# Altium schematic sheet [4]Storage.SchDoc
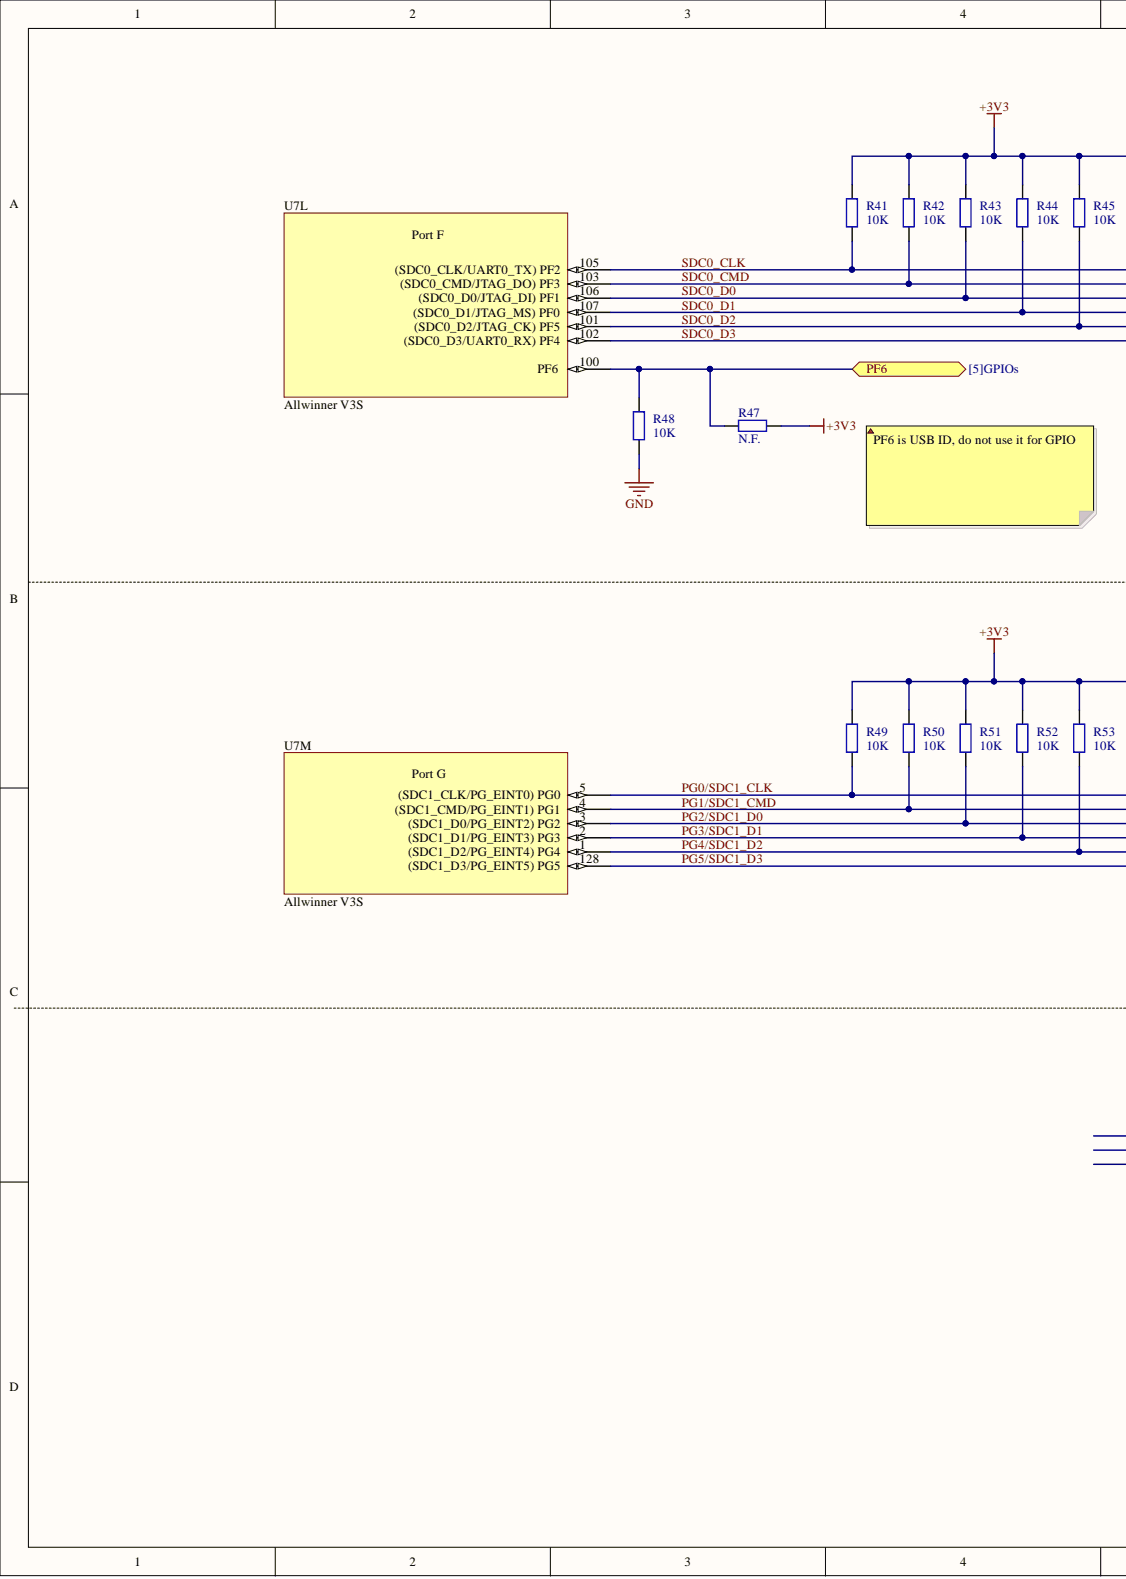
[diagram p0: [4]Storage.SchDoc, left]
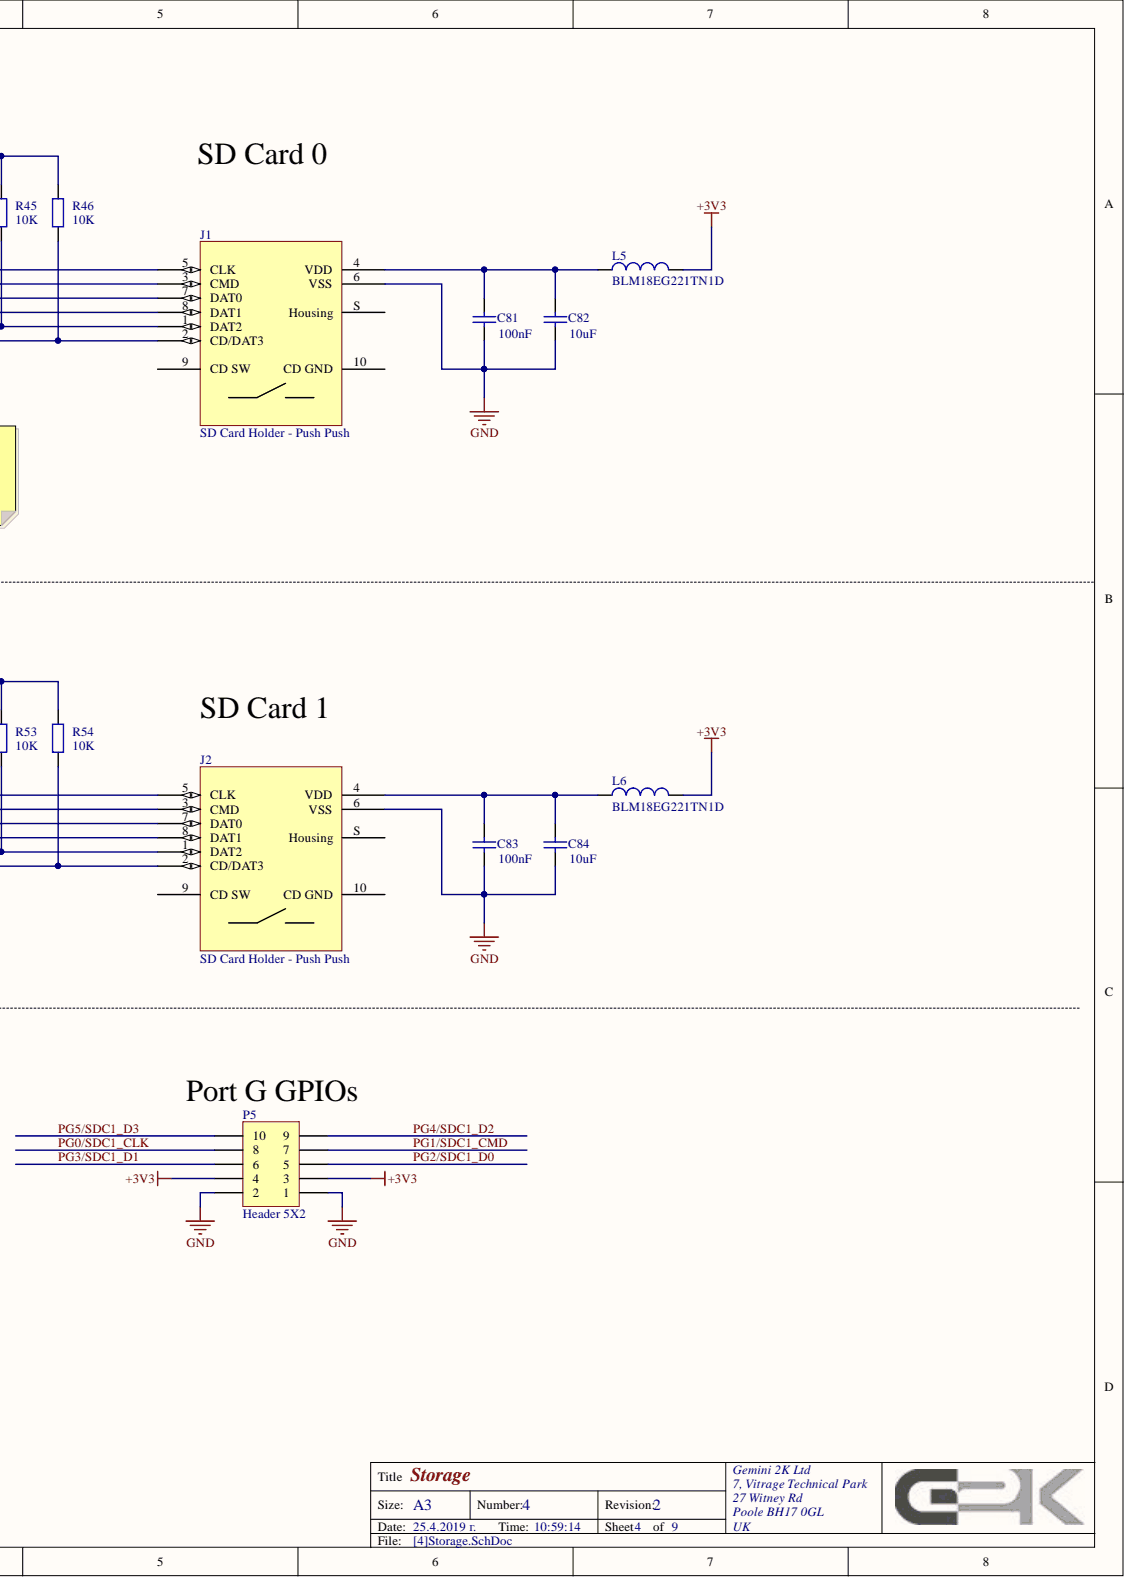
[diagram p1: [4]Storage.SchDoc, right]

## Parts
C81  value 100nF  [1608[0603]]  (hidden comment: 0603)
C82  value 10uF  [1608[0603]]  (hidden comment: 0603)
C83  value 100nF  [1608[0603]]  (hidden comment: 0603)
C84  value 10uF  [1608[0603]]  (hidden comment: 0603)
J1  SD Card Holder - Push Push  [Molex 5040771891]
J2  SD Card Holder - Push Push  [Molex 5040771891]
L5  BLM18EG221TN1D  [1608[0603]]
L6  BLM18EG221TN1D  [1608[0603]]
P5  Header 5X2  [HDR2X5]
R41  value 10K  [J1-0603]  (hidden comment: 0603)
R42  value 10K  [J1-0603]  (hidden comment: 0603)
R43  value 10K  [J1-0603]  (hidden comment: 0603)
R44  value 10K  [J1-0603]  (hidden comment: 0603)
R45  value 10K  [J1-0603]  (hidden comment: 0603)
R46  value 10K  [J1-0603]  (hidden comment: 0603)
R47  value N.F.  [J1-0603]  (hidden comment: 0603)
R48  value 10K  [J1-0603]  (hidden comment: 0603)
R49  value 10K  [J1-0603]  (hidden comment: 0603)
R50  value 10K  [J1-0603]  (hidden comment: 0603)
R51  value 10K  [J1-0603]  (hidden comment: 0603)
R52  value 10K  [J1-0603]  (hidden comment: 0603)
R53  value 10K  [J1-0603]  (hidden comment: 0603)
R54  value 10K  [J1-0603]  (hidden comment: 0603)
U7  Allwinner V3S  Manufacturer Name: Allwinner  Manufacturer Part No.: V3S  [LQFP128 E-PAD - Low Density]  (parts L,M)

## Ports
PF6

## Nets
+3V3: L5.2 L6.2 P5.3 P5.4 R41.2 R42.2 R43.2 R44.2 R45.2 R46.2 R47.1 R49.2 R50.2 R51.2 R52.2 R53.2 R54.2
GND: C81.1 C82.1 C83.1 C84.1 J1.6(VSS) J2.6(VSS) P5.1 P5.2 R48.1
PF6: R47.2 R48.2 U7.100(PF6) ; port PF6
PG0/SDC1_CLK: J2.5(CLK) P5.8 R49.1 U7.5((SDC1_CLK/PG_EINT0)_PG0)
PG1/SDC1_CMD: J2.3(CMD) P5.7 R50.1 U7.4((SDC1_CMD/PG_EINT1)_PG1)
PG2/SDC1_D0: J2.7(DAT0) P5.5 R51.1 U7.3((SDC1_D0/PG_EINT2)_PG2)
PG3/SDC1_D1: J2.8(DAT1) P5.6 R52.1 U7.2((SDC1_D1/PG_EINT3)_PG3)
PG4/SDC1_D2: J2.1(DAT2) P5.9 R53.1 U7.1((SDC1_D2/PG_EINT4)_PG4)
PG5/SDC1_D3: J2.2(CD/DAT3) P5.10 R54.1 U7.128((SDC1_D3/PG_EINT5)_PG5)
SDC0_CLK: J1.5(CLK) R41.1 U7.105((SDC0_CLK/UART0_TX)_PF2)
SDC0_CMD: J1.3(CMD) R42.1 U7.103((SDC0_CMD/JTAG_DO)_PF3)
SDC0_D0: J1.7(DAT0) R43.1 U7.106((SDC0_D0/JTAG_DI)_PF1)
SDC0_D1: J1.8(DAT1) R44.1 U7.107((SDC0_D1/JTAG_MS)_PF0)
SDC0_D2: J1.1(DAT2) R45.1 U7.101((SDC0_D2/JTAG_CK)_PF5)
SDC0_D3: J1.2(CD/DAT3) R46.1 U7.102((SDC0_D3/UART0_RX)_PF4)
NetC81_2: C81.2 C82.2 J1.4(VDD) L5.1
NetC83_2: C83.2 C84.2 J2.4(VDD) L6.1
N00101:
N00102:

Not connected: J1 9(CD_SW) 10(CD_GND) S(Housing); J2 9(CD_SW) 10(CD_GND) S(Housing)

## Library data of the parts
C81 C83 = Cap: Capacitor; Note: We have them in Bulgaria; Case Code (in): 0603; Component Kind: Standard; Distributor Link: http://uk.farnell.com/kemet/c0603x104k4ractu/cap-mlcc-x7r-100nf-16v-0603/dp/1414027; LatestRevisionDate: 17-Jul-2002; LatestRevisionNote: Re-released for DXP Platform.; Library Name: Miscellaneous Devices.IntLib; PackageDescription: Radial Cap, Thru-Hole; 2 Leads; 0.3 in Pin Spacing; PackageReference: RAD-0.3; Published: 8-Jun-2000; Signal Integrity: Cap; Simulation: CAP; Publisher: Altium Limited
C82 C84 = Cap: Capacitor; Case Code (in): 0603; Component Kind: Standard; Distributor Link: https://eu.mouser.com/ProductDetail/Taiyo-Yuden/JMK107ABJ106MA-T?qs=sGAEpiMZZMvsSlwiRhF8qtYrDJZp5VWM0KJHW32Kpw4hdLhJsFnoCA%3d%3d; LatestRevisionDate: 17-Jul-2002; LatestRevisionNote: Re-released for DXP Platform.; Library Name: Miscellaneous Devices.IntLib; PackageDescription: Radial Cap, Thru-Hole; 2 Leads; 0.3 in Pin Spacing; PackageReference: RAD-0.3; Published: 8-Jun-2000; Signal Integrity: Cap; Simulation: CAP; Publisher: Altium Limited
J1 J2 = SD Card: Manufacturer Name: Molex; Manufacturer Part No.: 5040771891; Component Kind: Standard; Distributor Link: https://uk.farnell.com/molex/5040771891/connector-micro-sd-1-1mm-8-position/dp/2214964; Library Name: PapayaPi.SchLib
L5 L6 = Inductor: Manufacturer Name: Murata; Note: We have them in Bulgaria; Component Kind: Standard; Description: Inductor; Distributor Link: http://uk.farnell.com/murata/blm18eg221tn1d/ferrite-bead-0-15ohm-1a-0603/dp/1515717; Library Name: Miscellaneous Devices.IntLib; Manufacturer Part No.: BLM18EG221TN1D; Signal Integrity: Inductor; Simulation: INDUCTOR
P5 = Header 5X2: Header, 5-Pin, Dual row; Component Kind: Standard; LatestRevisionDate: 17-Jul-2002; LatestRevisionNote: Re-released for DXP Platform.; Library Name: Miscellaneous Connectors.IntLib; Signal Integrity: Connector; Publisher: Altium Limited
R41-R54 = Res2: Resistor; Case Code (in): 0603; Component Kind: Standard; LatestRevisionDate: 17-Jul-2002; LatestRevisionNote: Re-released for DXP Platform.; Library Name: Miscellaneous Devices.IntLib; PackageDescription: Axial Device, Thru-Hole; 2 Leads; 0.4 in Pin Spacing; PackageReference: AXIAL-0.4; Published: 8-Jun-2000; Signal Integrity: Res; Simulation: RESISTOR; Publisher: Altium Limited
  R41-R46 R48-R54: Distributor Link: http://uk.farnell.com/multicomp/mcwr06x1002ftl/res-thick-film-10k-1-0-1w-0603/dp/2447230
U7 = Allwinner V3S: Component Kind: Standard; Library Name: Allwinner.SchLib
